# Altium schematic sheet Sheet1.SchDoc
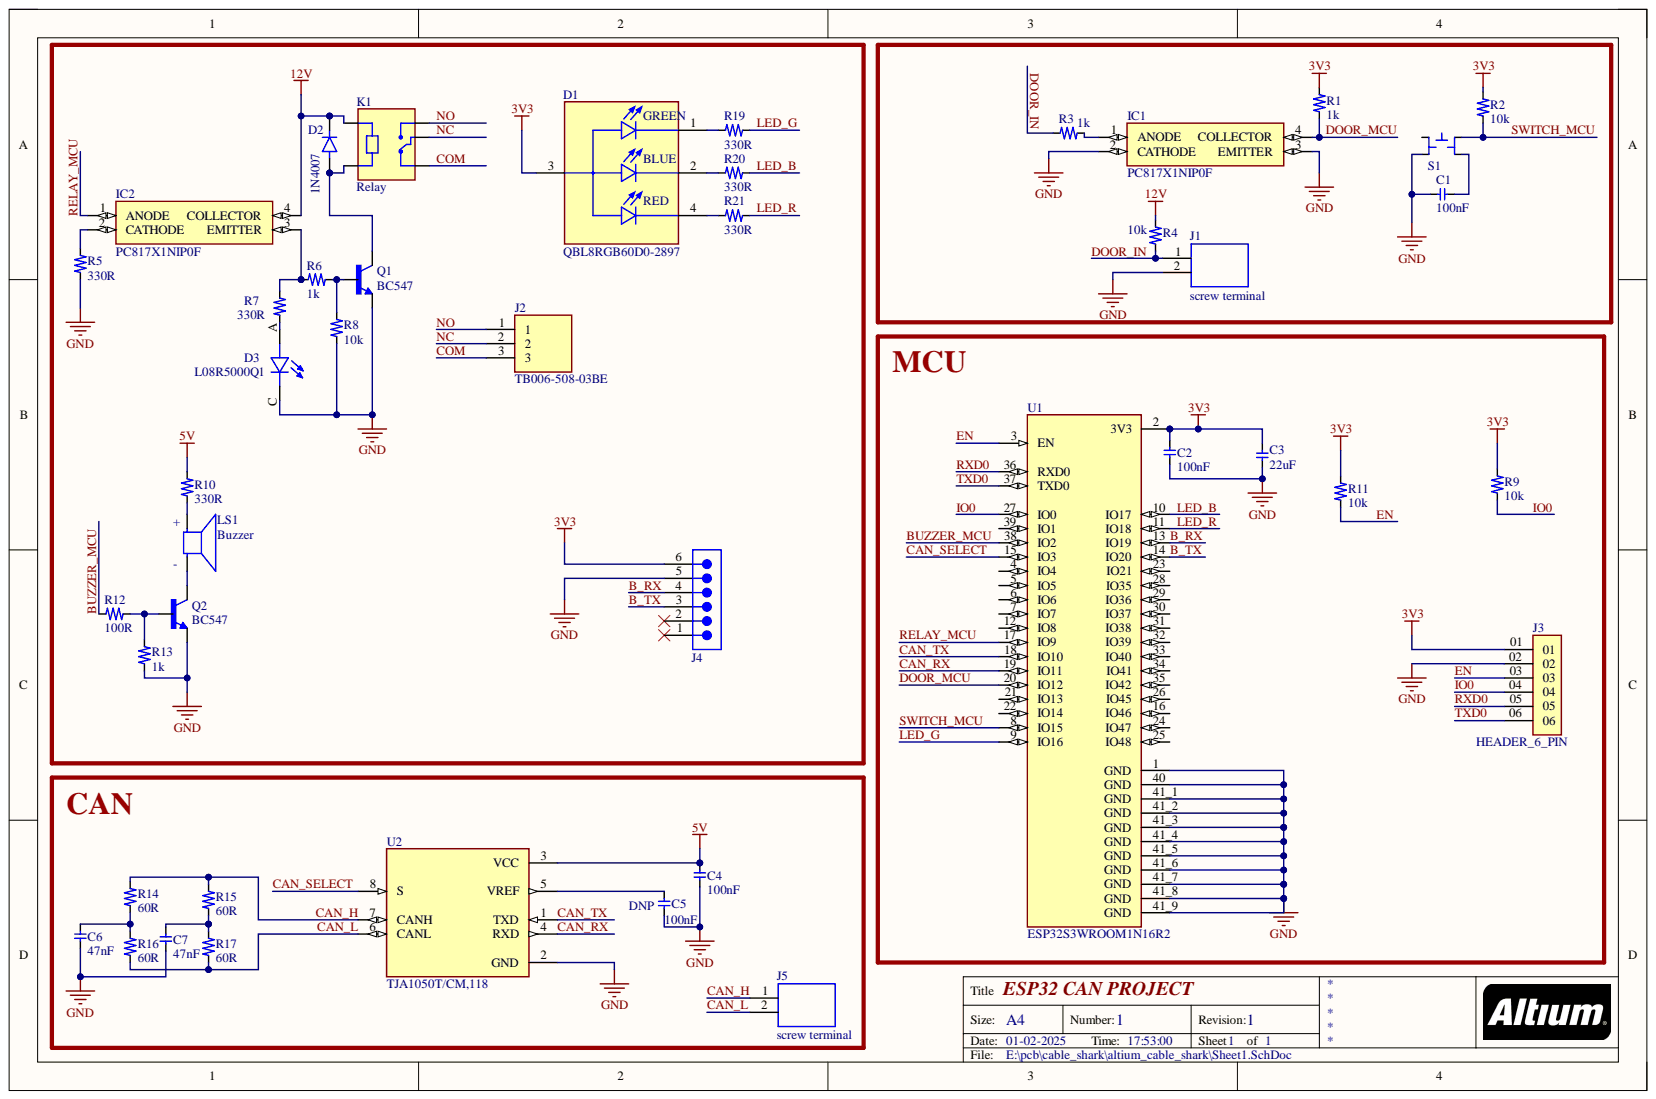
[diagram p0: Sheet1.SchDoc]

## Parts
C1  100nF  [100nF 16V]
C2  100nF  [100nF 16V]
C3  22uF  [22uF 16V]
C4  100nF  [100nF 16V]
C5  100nF  [100nF 16V]
C6  47nF  [47nF 16V]
C7  47nF  [47nF 16V]
D1  QBL8RGB60D0-2897  [LED_QBL8RGB60D0-2897]
D2  1N4007  [1N4007 SMD]
D3  L08R5000Q1  [LEDRD254W57D500H1070]
IC1  PC817X1NIP0F  [SOP254P975X460-4N]
IC2  PC817X1NIP0F  [SOP254P975X460-4N]
J1  screw terminal  [screw terminal 2 pin]
J2  TB006-508-03BE  [CUI_TB006-508-03BE]
J3  HEADER_6_PIN  [header 6 pin]
J4  62000611622  [62000611622]  (value not drawn)
J5  screw terminal  [screw terminal 2 pin]
K1  Relay  [Relay 5v]
LS1  Buzzer  [Buzzer]
Q1  BC547  [SOT23]
Q2  BC547  [SOT23]
R1  1k  [1k 0805]
R2  10k  [10k 0805]
R3  1k  [1k 0805]
R4  10k  [10k 0805]
R5  330R  [330R 0805]
R6  1k  [1k 0805]
R7  330R  [330R 0805]
R8  10k  [10k 0805]
R9  10k  [10k 0805]
R10  330R  [330R 0805]
R11  10k  [10k 0805]
R12  100R  [100R 0805]
R13  1k  [1k 0805]
R14  60R  [60R 0805]
R15  60R  [60R 0805]
R16  60R  [60R 0805]
R17  60R  [60R 0805]
R19  330R  [330R 0805]
R20  330R  [330R 0805]
R21  330R  [330R 0805]
S1  Switch-TK-034DA-4 Pin 3.5mm  [Switch-TK-034DA-4]  (value not drawn)
U1  ESP32S3WROOM1N16R2  [ESP32S3WROOM1N16R2]
U2  TJA1050T/CM,118  [SOIC127P600X175-8N]

## Nets
3V3: C2.2 C3.2 D1.3 J3.01 J4.6 R1.2 R2.2 R9.2 R11.2 U1.2(3V3)
5V: C4.2 R10.2 U2.3(VCC)
12V: D2.C IC2.4(COLLECTOR) K1.A1 R4.2
GND: C1.2 C2.1 C3.1 C4.1 C5.1 C6.1 C7.1 D3.C IC1.2(CATHODE) IC1.3(EMITTER) J1.2 J3.02 J4.5 Q1.2 Q2.2 R5.2 R8.1 R13.1 S1.3 U1.1(GND) U1.40(GND) U1.41_1(GND) U1.41_2(GND) U1.41_3(GND) U1.41_4(GND) U1.41_5(GND) U1.41_6(GND) U1.41_7(GND) U1.41_8(GND) U1.41_9(GND) U2.2(GND)
B_RX: J4.4 U1.13(IO19)
B_TX: J4.3 U1.14(IO20)
BUZZER_MCU: R12.1 U1.38(IO2)
CAN_H: J5.1 R14.2 R15.1 U2.7(CANH)
CAN_L: J5.2 R16.1 R17.1 U2.6(CANL)
CAN_RX: U1.19(IO11) U2.4(RXD)
CAN_SELECT: U1.15(IO3) U2.8(S)
CAN_TX: U1.18(IO10) U2.1(TXD)
COM: J2.3 K1.NC
DOOR_IN: J1.1 R3.2 R4.1
DOOR_MCU: IC1.4(COLLECTOR) R1.1 U1.20(IO12)
EN: J3.03 R11.1 U1.3(EN)
IO0: J3.04 R9.1 U1.27(IO0)
LED_B: R20.2 U1.10(IO17)
LED_G: R19.2 U1.9(IO16)
LED_R: R21.2 U1.11(IO18)
NC: J2.2 K1.COM
NO: J2.1 K1.NO
RELAY_MCU: IC2.1(ANODE) U1.17(IO9)
RXD0: J3.05 U1.36(RXD0)
SWITCH_MCU: R2.1 S1.2 U1.8(IO15)
TXD0: J3.06 U1.37(TXD0)
NetC1_1: C1.1 S1.1
NetC5_2: C5.2 U2.5(VREF)
NetC6_2: C6.2 R14.1 R16.2
NetC7_2: C7.2 R15.2 R17.2
NetD1_1: D1.1 R19.1
NetD1_2: D1.2 R20.1
NetD1_4: D1.4 R21.1
NetD2_A: D2.A K1.A2 Q1.3
NetD3_A: D3.A R7.2
NetIC1_1: IC1.1(ANODE) R3.1
NetIC2_2: IC2.2(CATHODE) R5.1
NetIC2_3: IC2.3(EMITTER) R6.2 R7.1
NetLS1_1: LS1.1 R10.1
NetLS1_2: LS1.2 Q2.3
NetQ1_1: Q1.1 R6.1 R8.2
NetQ2_1: Q2.1 R12.2 R13.2

Not connected: J4 1 2; S1 4; U1 4(IO4) 5(IO5) 6(IO6) 7(IO7) 12(IO8) 16(IO46) 21(IO13) 22(IO14) 23(IO21) 24(IO47) 25(IO48) 26(IO45) 28(IO35) 29(IO36) 30(IO37) 31(IO38) 32(IO39) 33(IO40) 34(IO41) 35(IO42) 39(IO1)

## Library data of the parts
C1 C2 C4 C5 = 100nF: 0805 16V; Component Kind: Standard; Library Name: capacitors.SchLib
C3 = 22uF: 0805 25V; Component Kind: Standard; Library Name: capacitors.SchLib
C6 C7 = 47nF: 0805 16V; Component Kind: Standard; Library Name: capacitors.SchLib
D2 = 1N4007: 1N4007 SMD; Component Kind: Standard; Library Name: diode.SchLib
D3 = L08R5000Q1: LED, 5MM, ORANGE; LED / Lamp Size: 5mm / T-1 3/4; LED Colour: Orange; Typ Luminous Intensity: 4.3mcd; Viewing Angle: ...; MF: LED Technology; Availability: In Stock; Check_prices: https://www.snapeda.com/parts/L08R5000Q1/LED+Technology/view-part/?ref=eda; Component Kind: Standard; Library Name: diode.SchLib; MP: L08R5000Q1; Package: None; Price: None; SnapEDA_Link: https://www.snapeda.com/parts/L08R5000Q1/LED+Technology/view-part/?ref=snap
IC1 IC2 = PC817X1NIP0F: Optoisolator Transistor Output 5000Vrms 1 Channel 4-SMD; MF: Sharp Microelectronics; Check_prices: https://www.snapeda.com/parts/PC817X1NIP0F/Sharp+Microelectronics/view-part/?ref=eda; Component Kind: Standard; Library Name: IC.SchLib; MP: PC817X1NIP0F; Package: SMD-4 Sharp Microelectronics; SnapEDA_Link: https://www.snapeda.com/parts/PC817X1NIP0F/Sharp+Microelectronics/view-part/?ref=snap
J1 J5 = screw terminal: screw terminal 2 pin; Component Kind: Standard; Library Name: Schlib.SchLib
J2 = TB006-508-03BE: 2~24 Poles, Screw Type, Horizontal, 5.08 Pitch, 26~14 (AWG), Terminal Block Connector; MF: CUI Devices; Availability: In Stock; Check_prices: https://www.snapeda.com/parts/TB006-508-03BE/CUI+Devices/view-part/?ref=eda; Component Kind: Standard; CUI_purchase_URL: https://www.cuidevices.com/product/interconnect/connectors/terminal-blocks/tb006-508-series?utm_source=snapeda.com&utm_medium=referral&utm_campaign=snapedaBOM; Library Name: connectors.SchLib; MP: TB006-508-03BE; Package: None; Price: None; Purchase-URL: https://pricing.snapeda.com/search/part/TB006-508-03BE/?ref=eda; SnapEDA_Link: https://www.snapeda.com/parts/TB006-508-03BE/CUI+Devices/view-part/?ref=snap
J3 = HEADER_6_PIN: 2.54mm; MF: Samtec Inc.; MP: TSW-106-07-L-S; Availability: Unavailable; Component Kind: Standard; Library Name: connectors.SchLib; Package: None; Price: None
J4 = 62000611622: WR-WTB Male Vertical Shrouded Header, pitch 2mm, 6pins; Manufacturer: Wurth Elektronik; Contact Resistance: 20mOhm; Rated Current: 2A; Withstanding Voltage: 800VAC; Working Voltage: 125VAC; Category: Wire-to-Board Connectors; Component Kind: Standard; ComponentLink1Description: Website Link; ComponentLink1URL: https://www.we-online.com/redexpert/article/62000611622?ad; ComponentLink2Description: Datasheet Link; ComponentLink2URL: https://www.we-online.com/redexpert/spec/62000611622?ad; Gender: Male; Height: 6.1mm; Length: 14mm; Library Name: connectors.SchLib; Manufacturer Part Number: 62000611622; Match Code: WR-WTB; Mount: Through Hole; Number of Pins: 6; Number of Rows: 1; Operating Temperature Max: 85°C; Operating Temperature Min: -25°C; Orientation: Vertical; Packaging: Bag; Pitch: 2mm; Width: 4.7mm
K1 = Relay: Relay 5V; Component Kind: Standard; Library Name: Schlib.SchLib
LS1 = Buzzer: PS1240P02BT Buzzers Transducer, Externally Driven Piezo 3V 4kHz 60dB @ 3V, 10cm Through Hole PC Pins; MF: TDK; Availability: Unavailable; Component Kind: Standard; Library Name: miscellaneous.SchLib; MP: PS1240P02BT; Package: 2020 TDK; Price: None; SnapEDA_Link: https://www.snapeda.com/parts/PS1240P02BT/TDK/view-part/53420/?ref=snap
Q1 Q2 = BC547 smd: Bipolar _BJT_ Transistor NPN 45V 100mA 300MHz 500mW; MF: ON Semiconductor; Availability: Unavailable; Component Kind: Standard; Library Name: transistors.SchLib; MP: BC547; Package: TO-92 ON Semiconductor; Price: None; SnapEDA_Link: https://www.snapeda.com/parts/BC547/ON%20Semiconductor/view-part/608530/?ref=snap
R1 R3 R6 R13 = 1k: 0805; Component Kind: Standard; Library Name: resistor.SchLib
R2 R4 R8 R9 R11 = 10k: 0805; Component Kind: Standard; Library Name: resistor.SchLib
R5 R7 R10 R19-R21 = 330R: 0805; Component Kind: Standard; Library Name: resistor.SchLib
R12 = 100R: 0805; Component Kind: Standard; Library Name: resistor.SchLib
R14-R17 = 60R: 0805; Component Kind: Standard; Library Name: resistor.SchLib
S1 = Switch-TK-034DA-4 Pin 3.5mm: SMD; Component Kind: Standard; Library Name: switches.SchLib
U2 = TJA1050T/CM,118: 1/1 Transceiver Half CANbus 8-SO; MF: NXP USA; Availability: In Stock; Check_prices: https://www.snapeda.com/parts/TJA1050T/CM,118/NXP%20USA%20Inc./view-part/304529/?ref=eda; Component Kind: Standard; Library Name: IC.SchLib; MP: TJA1050T/CM,118; Package: SO-8 NXP Semiconductors; Price: None; Purchase-URL: https://pricing.snapeda.com/search/part/TJA1050T/CM,118/?ref=eda; SnapEDA_Link: https://www.snapeda.com/parts/TJA1050T/CM,118/NXP%20USA%20Inc./view-part/304529/?ref=snap
D1 = QBL8RGB60D0-2897: Availability: Unavailable; Component Kind: Standard; Library Name: diode.SchLib; MP: QBL8RGB60D0-2897; Package: None; Price: None
U1 = ESP32S3WROOM1N16R2: Component Kind: Standard; Library Name: uC.SchLib
